# Altium schematic sheet 发热手套.SchDoc
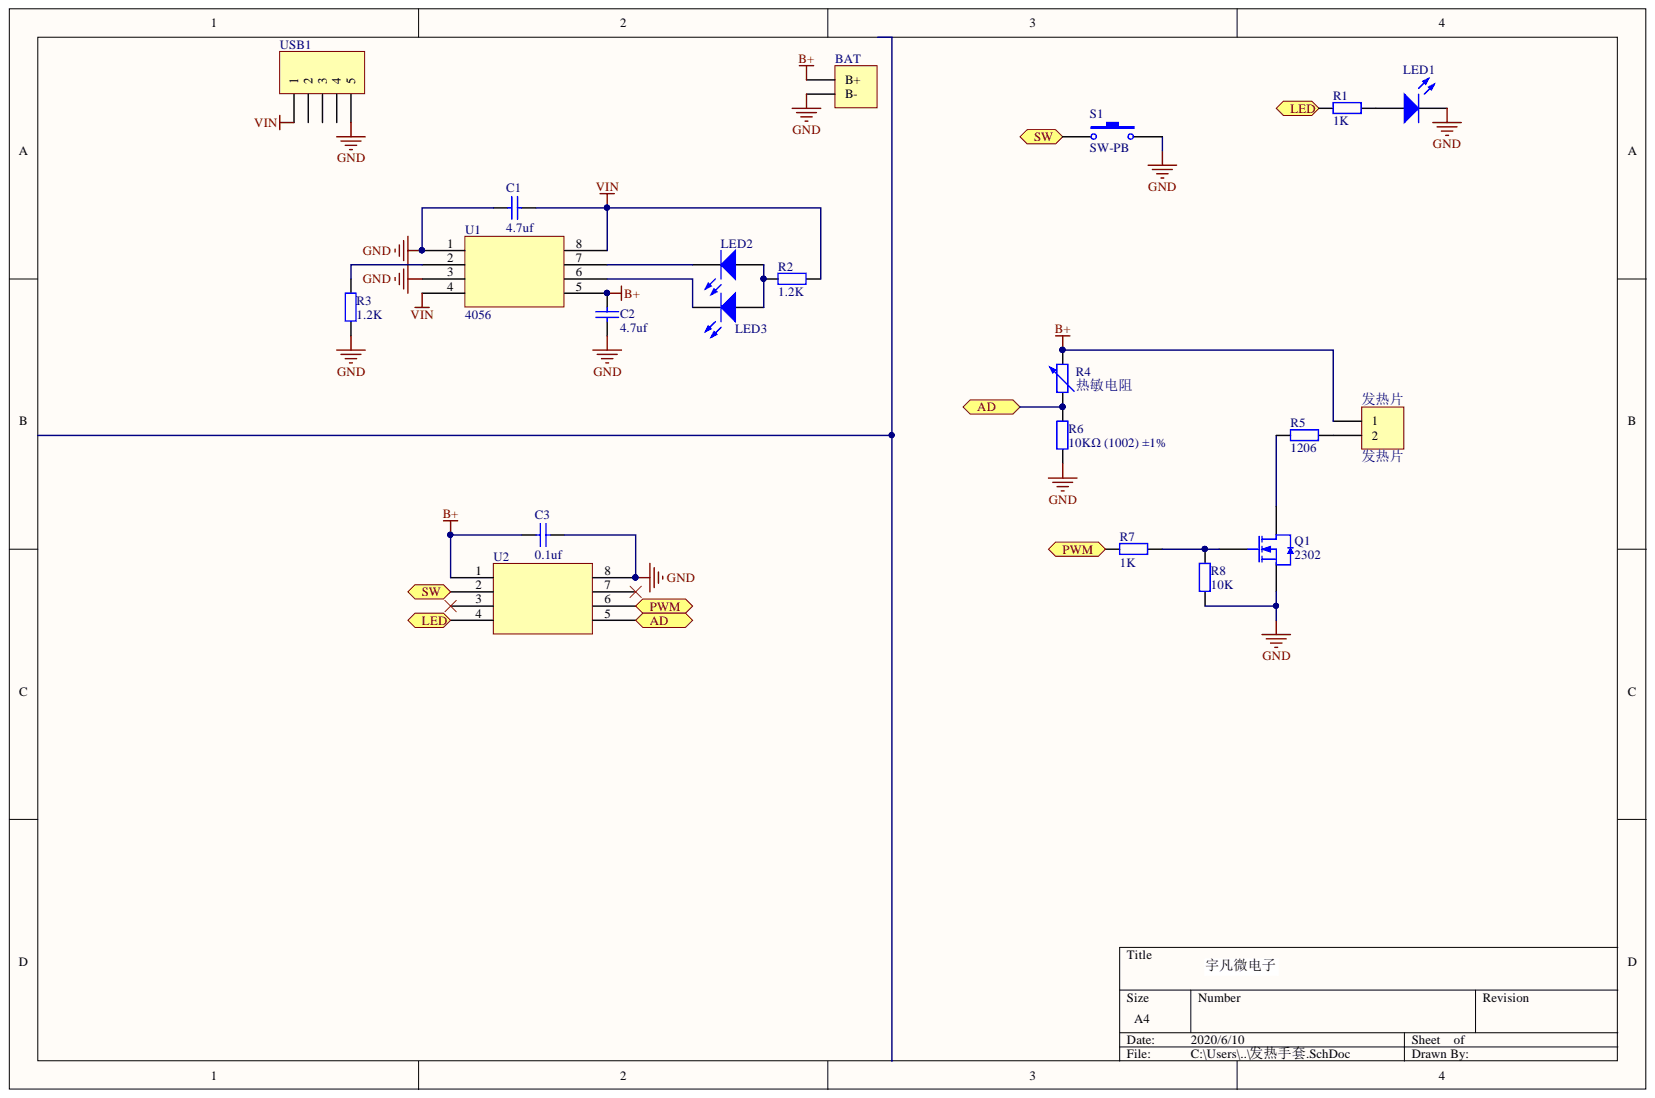
[diagram p0: 发热手套.SchDoc]

## Parts
BAT  (no value)  [HDR1X2]
C1  4.7uf  [0603_C]
C2  4.7uf  [0603_C]
C3  0.1uf  [0603_C]
LED1  (no value)  [0603_LED_S1]
LED2  (no value)  [0603_LED_S1]
LED3  (no value)  [0603_LED_S1]
Q1  2302  [SOT-23-3L]
R1  1K  [0603_R]
R2  1.2K  [0603_R]
R3  1.2K  [0603_R]
R4  热敏电阻  [HDR1X2]
R5  1206  [1206_R]
R6  10KΩ (1002) ±1%  [0603_R]
R7  1K  [0603_R]
R8  10K  [0603_R]
S1  SW-PB  [SW]
U1  4056  [SOIC-8_150mil]
U2  (no value)  [SOIC-8_150mil]
USB1  (no value)  [MICRO-USB-SMD_MICROXNJ]
发热片  发热片  [HDR1X2]

## Ports
AD, LED, PWM, SW

## Nets
B+: BAT.1 C2.2 C3.1 R4.2 U1.5 U2.1 发热片.1
GND: BAT.2 C1.1 C2.1 C3.2 LED1.K Q1.2 R3.2 R6.2 R8.2 S1.2 U1.1 U1.3 U2.8 USB1.5
VIN: C1.2 R2.1 U1.4 U1.8 USB1.1
AD: R4.1 R6.1 U2.5 ; port AD
LED: R1.1 U2.4 ; port LED
PWM: R7.2 U2.6 ; port PWM
SW: S1.1 U2.2 ; port SW
NetLED1_A: LED1.A R1.2
NetLED2_A: LED2.A LED3.A R2.2
NetLED2_K: LED2.K U1.7
NetLED3_K: LED3.K U1.6
NetQ1_1: Q1.1 R7.1 R8.1
NetQ1_3: Q1.3 R5.1
NetR3_1: R3.1 U1.2
NetR5_2: R5.2 发热片.2
N00003:

Not connected: U2 3 7; USB1 2 3 4

## Library data of the parts
BAT 发热片 = Header 2: Header, 2-Pin; Component Kind: Standard; LatestRevisionDate: 17-Jul-2002; LatestRevisionNote: Re-released for DXP Platform.; Library Name: Miscellaneous Connectors.IntLib; Signal Integrity: Connector; Publisher: Altium Limited
C1-C3 = 0603 1uF (105) 10% 50V: 贴片电容; Component Kind: Standard; ComponentLink1Description: 供应商链接; ComponentLink1URL: http://www.szlcsc.com/product/details_16531.html; Library Name: Cap.SchLib; Package: 0603; Supplier: LC; SuppliersPartNumber: C15849
LED1-LED3 = 0805 蓝灯: 发光二极管; Component Kind: Standard; ComponentLink1Description: 供应商链接; ComponentLink1URL: http://www.szlcsc.com/product/details_2666.html; Library Name: LED.SchLib; Package: 0805; Supplier: LC; SuppliersPartNumber: C2293
Q1 = AO3400A N道沟 X0YV1T SOT-23: MOS(场效应管); Component Kind: Standard; ComponentLink1Description: 供应商链接; ComponentLink1URL: http://www.szlcsc.com/product/details_21629.html; Library Name: MOS.SchLib; Package: SOT-23; Supplier: LC; SuppliersPartNumber: C20917
R1-R3 R6-R8 = 0603 0Ω (0R0) 1%: 贴片电阻; Component Kind: Standard; ComponentLink1Description: 供应商链接; ComponentLink1URL: http://www.szlcsc.com/product/details_21903.html; Library Name: RES.SchLib; Package: 0603; Supplier: LC; SuppliersPartNumber: C21189
R4 = Res Adj2: Variable Resistor; Set Position: 0.5; Component Kind: Standard; LatestRevisionDate: 17-Jul-2002; LatestRevisionNote: Re-released for DXP Platform.; Library Name: Miscellaneous Devices.IntLib; PackageDescription: Axial Device, Thru-Hole; 2 Leads; 0.6 in Pin Spacing; PackageReference: AXIAL-0.6; Published: 8-Jun-2000; Signal Integrity: Res Adj; Simulation: VRES; Publisher: Altium Limited
R5 = 1206 0Ω (0R0) 1%: 贴片电阻; Component Kind: Standard; ComponentLink1Description: 供应商链接; ComponentLink1URL: http://www.szlcsc.com/product/details_18576.html; Library Name: RES.SchLib; Package: 1206; Supplier: LC; SuppliersPartNumber: C17888
S1 = SW-PB: Switch; Component Kind: Standard; LatestRevisionDate: 17-Jul-2002; LatestRevisionNote: Re-released for DXP Platform.; Library Name: Miscellaneous Devices.IntLib; PackageDescription: Switch; 2 Leads; PackageReference: SPST-2; Published: 24-Mar-1999; Publisher: Altium Limited
U1 U2 = ACS712ELCTR-20A-T_[C10681]: 传感器; Component Kind: Standard; ComponentLink1Description: 供应商链接; ComponentLink1URL: http://www.szlcsc.com/product/details_11225.html; Library Name: IC.SchLib; Package: SOIC-8_150mil; Supplier: LC; SuppliersPartNumber: C10681
USB1 = Header 5: Header, 5-Pin; Component Kind: Standard; LatestRevisionDate: 17-Jul-2002; LatestRevisionNote: Re-released for DXP Platform.; Library Name: Miscellaneous Connectors.IntLib; Signal Integrity: Connector; Publisher: Altium Limited
